# Altium schematic sheet instaspin_bldc_driver.SchDoc
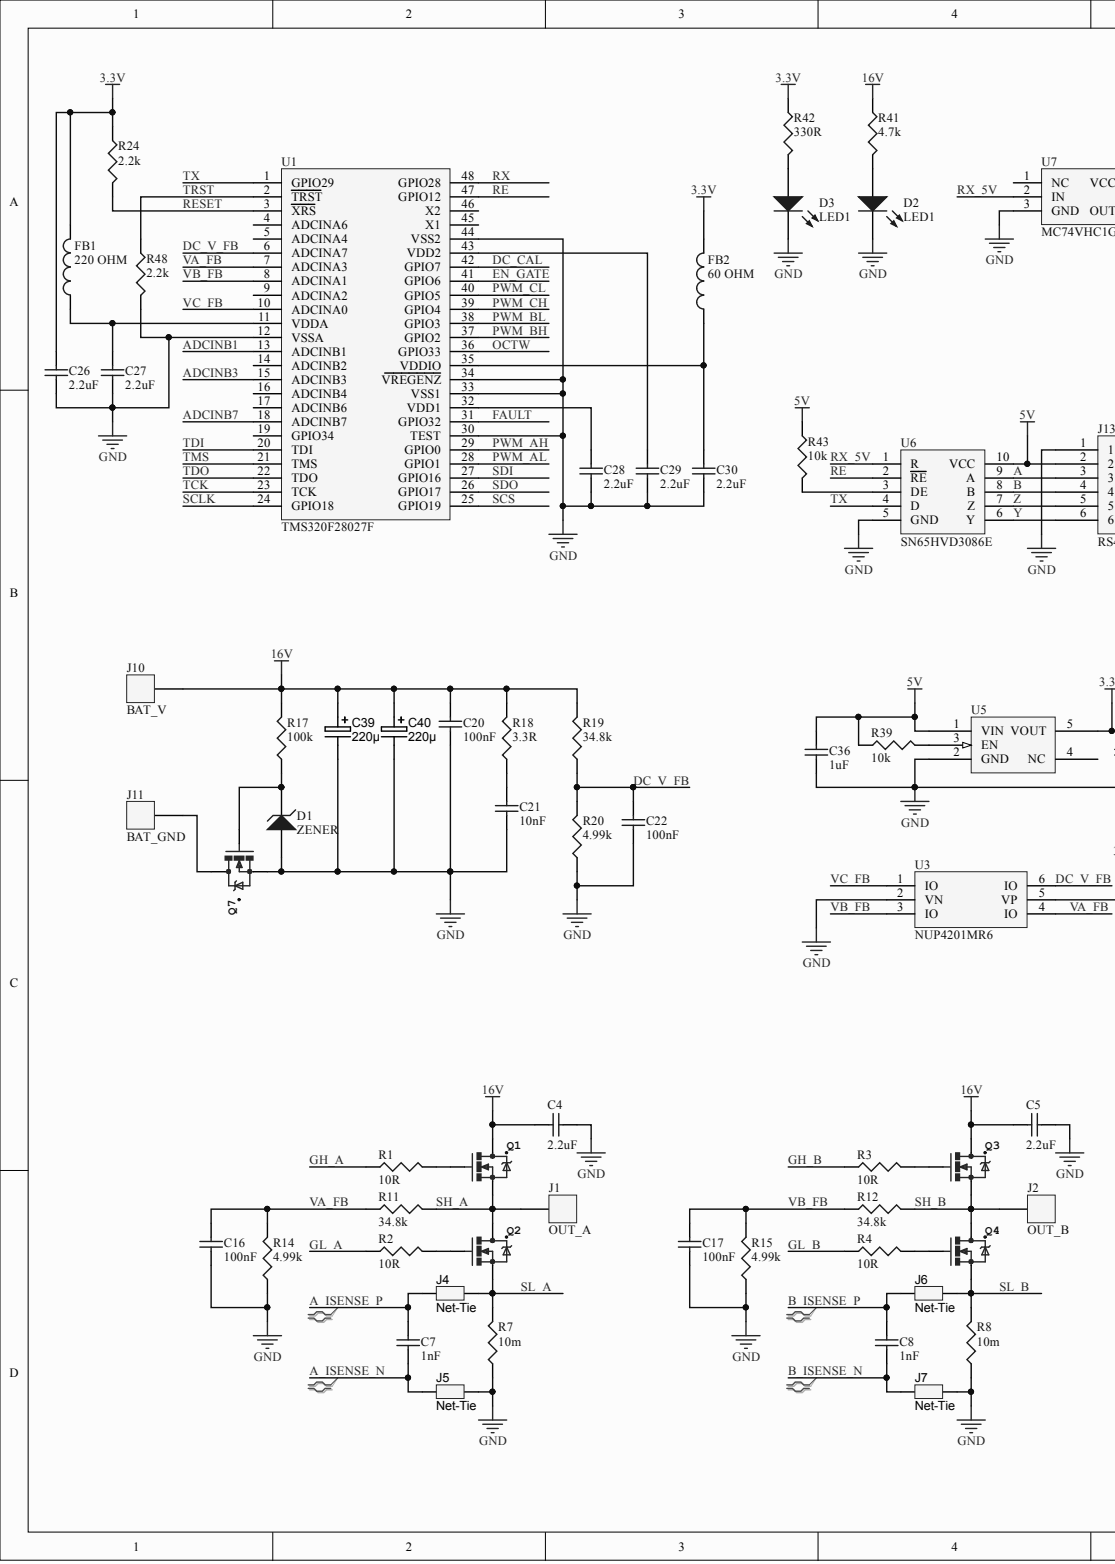
[diagram p0: instaspin_bldc_driver.SchDoc, left]
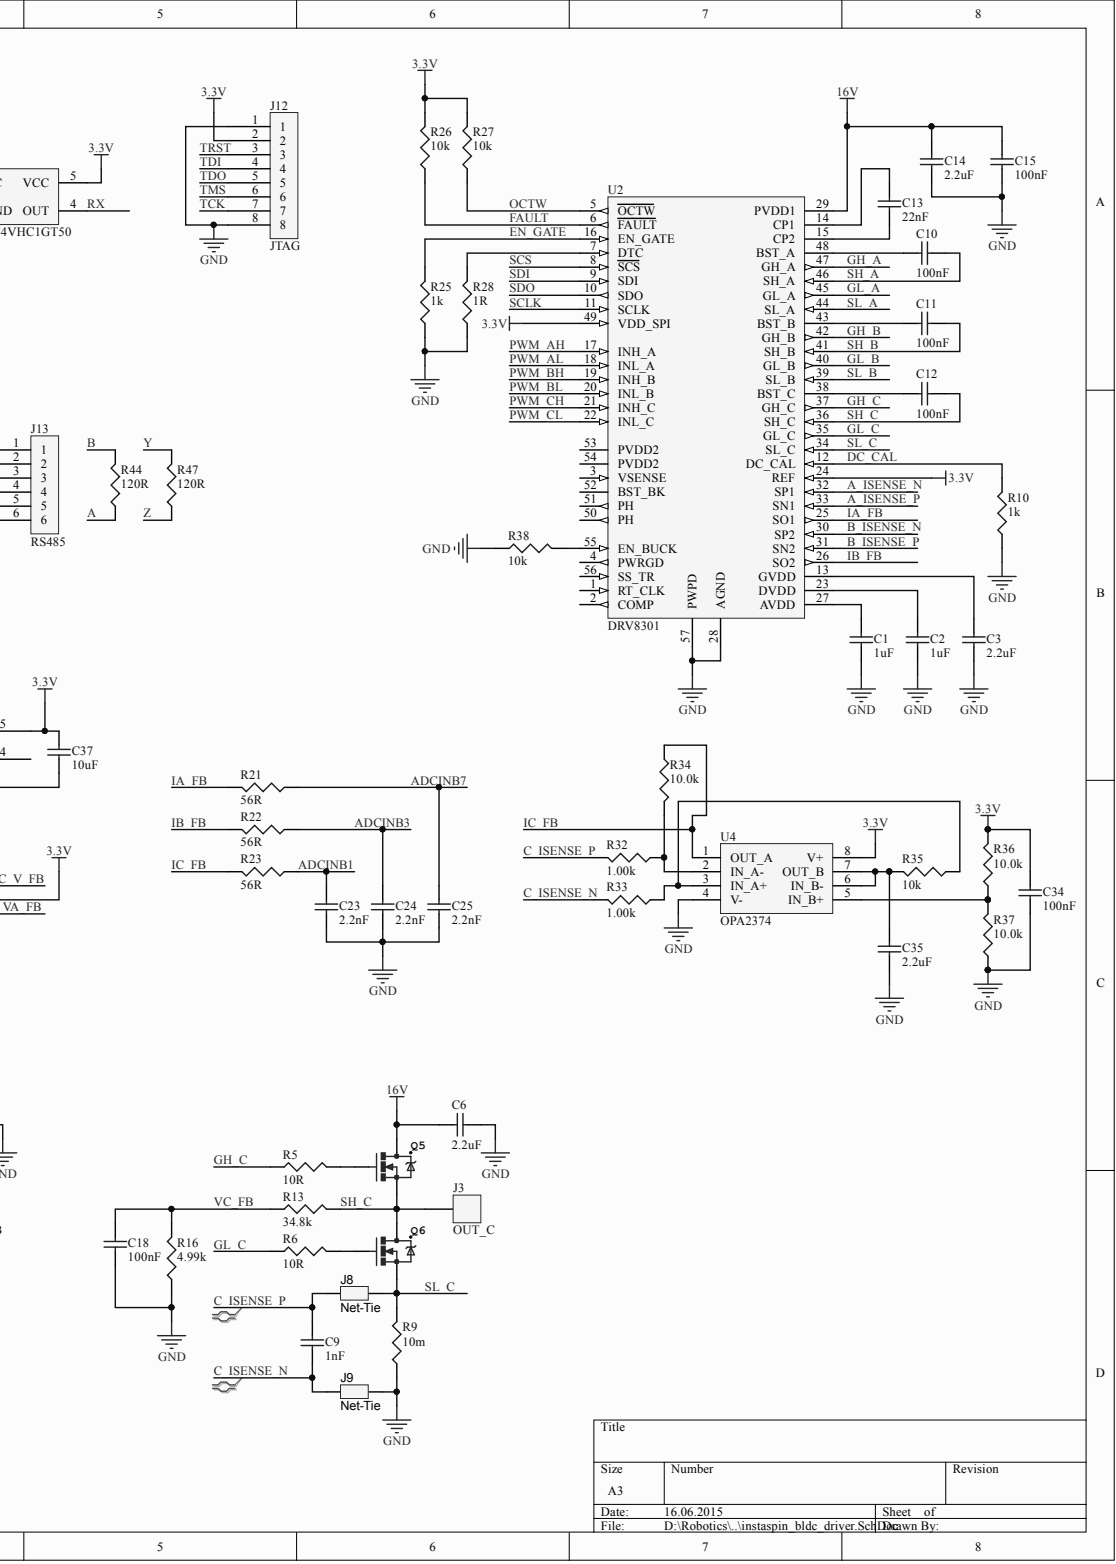
[diagram p1: instaspin_bldc_driver.SchDoc, right]

## Parts
C1  1uF  [1608[0603]]
C2  1uF  [1608[0603]]
C3  2.2uF  [1608[0603]]
C4  2.2uF  [C1206]
C5  2.2uF  [C1206]
C6  2.2uF  [C1206]
C7  1nF  [1608[0603]]
C8  1nF  [1608[0603]]
C9  1nF  [1608[0603]]
C10  100nF  [1608[0603]]
C11  100nF  [1608[0603]]
C12  100nF  [1608[0603]]
C13  22nF  [1608[0603]]
C14  2.2uF  [C1206]
C15  100nF  [1608[0603]]
C16  100nF  [1608[0603]]
C17  100nF  [1608[0603]]
C18  100nF  [1608[0603]]
C20  100nF  [1608[0603]]
C21  10nF  [1608[0603]]
C22  100nF  [1608[0603]]
C23  2.2nF  [1608[0603]]
C24  2.2nF  [1608[0603]]
C25  2.2nF  [1608[0603]]
C26  2.2uF  [1608[0603]]
C27  2.2uF  [1608[0603]]
C28  2.2uF  [1608[0603]]
C29  2.2uF  [1608[0603]]
C30  2.2uF  [1608[0603]]
C34  100nF  [1608[0603]]
C35  2.2uF  [1608[0603]]
C36  1uF  [1608[0603]]
C37  10uF  [C1206]
C39  220µ  [CAP_ELEC_6.3x4.2]
C40  220µ  [CAP_ELEC_6.3x4.2]
D1  ZENER  [SODFL370X135-2N]
D2  LED1  [1608[0603]]
D3  LED1  [1608[0603]]
FB1  220 OHM  [INDC1608L]
FB2  60 OHM  [INDC1608L]
J1  OUT_A  [POWER-PAD]
J2  OUT_B  [POWER-PAD]
J3  OUT_C  [POWER-PAD]
J4  Net-Tie  [Net-Tie_10mil]
J5  Net-Tie  [Net-Tie_10mil]
J6  Net-Tie  [Net-Tie_10mil]
J7  Net-Tie  [Net-Tie_10mil]
J8  Net-Tie  [Net-Tie_10mil]
J9  Net-Tie  [Net-Tie_10mil]
J10  BAT_V  [POWER-PAD]
J11  BAT_GND  [POWER-PAD]
J12  JTAG  [MICRO-MATCH-8]
J13  RS485  [MICRO-MATCH-6]
Q1  MOSFET-N  [SOT669]  (value not drawn)
Q2  MOSFET-N  [SOT669]  (value not drawn)
Q3  MOSFET-N  [SOT669]  (value not drawn)
Q4  MOSFET-N  [SOT669]  (value not drawn)
Q5  MOSFET-N  [SOT669]  (value not drawn)
Q6  MOSFET-N  [SOT669]  (value not drawn)
Q7  MOSFET-N  [SOT669]  (value not drawn)
R1  10R  [J1-0603]
R2  10R  [J1-0603]
R3  10R  [J1-0603]
R4  10R  [J1-0603]
R5  10R  [J1-0603]
R6  10R  [J1-0603]
R7  10m  [2512M]
R8  10m  [2512M]
R9  10m  [2512M]
R10  1k  [J1-0603]
R11  34.8k  [J1-0603]
R12  34.8k  [J1-0603]
R13  34.8k  [J1-0603]
R14  4.99k  [J1-0603]
R15  4.99k  [J1-0603]
R16  4.99k  [J1-0603]
R17  100k  [J1-0603]
R18  3.3R  [C1206]
R19  34.8k  [J1-0603]
R20  4.99k  [J1-0603]
R21  56R  [J1-0603]
R22  56R  [J1-0603]
R23  56R  [J1-0603]
R24  2.2k  [J1-0603]
R25  1k  [J1-0603]
R26  10k  [J1-0603]
R27  10k  [J1-0603]
R28  1R  [J1-0603]
R32  1.00k  [J1-0603]
R33  1.00k  [J1-0603]
R34  10.0k  [J1-0603]
R35  10k  [J1-0603]
R36  10.0k  [J1-0603]
R37  10.0k  [J1-0603]
R38  10k  [J1-0603]
R39  10k  [J1-0603]
R41  4.7k  [J1-0603]
R42  330R  [J1-0603]
R43  10k  [J1-0603]
R44  120R  [J1-0603]
R47  120R  [J1-0603]
R48  2.2k  [J1-0603]
U1  TMS320F28027F  [PQFP48]
U2  DRV8301  [SO56_POWERPAD]
U3  NUP4201MR6  [TSOP-6]
U4  OPA2374  [SOT23-8]
U5  LDO-SOT23-5  [SOT23-5]  (value not drawn)
U6  SN65HVD3086E  [SOP10]
U7  MC74VHC1GT50  [SC70-5]

## Nets
3.3V: C26.2 C34.2 C37.2 FB1.2 FB2.2 J12.2 R24.1 R26.2 R27.2 R36.2 R42.1 U2.24(REF) U2.49(VDD_SPI) U3.5(VP) U4.8(V+) U5.5(VOUT) U7.5(VCC)
5V: C36.2 J13.2 R39.1 R43.1 U5.1(VIN) U6.10(VCC)
16V: C4.1 C5.1 C6.1 C14.2 C15.1 C20.2 C39.1 C40.1 J10.1 Q1.D Q3.D Q5.D R17.2 R18.2 R19.2 R41.1 U2.29(PVDD1)
GND: C1.1 C2.1 C3.1 C4.2 C5.2 C6.2 C14.1 C15.2 C16.1 C17.1 C18.1 C20.1 C21.1 C22.1 C23.1 C24.1 C25.1 C26.1 C27.1 C28.1 C29.1 C30.1 C34.1 C35.1 C36.1 C37.1 C39.2 C40.2 D1.2 D2.2 D3.2 J5.2 J7.2 J9.2 J12.1 J12.8 J13.1 Q7.S R7.1 R8.1 R9.1 R10.1 R14.1 R15.1 R16.1 R20.1 R25.1 R28.1 R37.1 R38.1 R48.2 U1.12(VSSA) U1.30(TEST) U1.33(VSS1) U1.34(VREGENZ) U1.44(VSS2) U2.28(AGND) U2.57(PWPD) U3.2(VN) U4.4(V-) U5.2(GND) U6.5(GND) U7.3(GND)
A: J13.3 R44.1 U6.9(A)
A_ISENSE_N: C7.1 J5.1 U2.32(SP1)
A_ISENSE_P: C7.2 J4.1 U2.33(SN1)
ADCINB1: C23.2 R23.1 U1.13(ADCINB1)
ADCINB3: C24.2 R22.1 U1.15(ADCINB3)
ADCINB7: C25.2 R21.1 U1.18(ADCINB7)
B: J13.4 R44.2 U6.8(B)
B_ISENSE_N: C8.1 J7.1 U2.30(SP2)
B_ISENSE_P: C8.2 J6.1 U2.31(SN2)
C_ISENSE_N: C9.1 J9.1 R33.2
C_ISENSE_P: C9.2 J8.1 R32.2
DC_CAL: R10.2 U1.42(GPIO7) U2.12(DC_CAL)
DC_V_FB: C22.2 R19.1 R20.2 U1.6(ADCINA7) U3.6(IO)
EN_GATE: R25.2 U1.41(GPIO6) U2.16(EN_GATE)
FAULT: R26.1 U1.31(GPIO32) U2.6(FAULT)
GH_A: R1.1 U2.47(GH_A)
GH_B: R3.1 U2.42(GH_B)
GH_C: R5.1 U2.37(GH_C)
GL_A: R2.1 U2.45(GL_A)
GL_B: R4.1 U2.40(GL_B)
GL_C: R6.1 U2.35(GL_C)
IA_FB: R21.2 U2.25(SO1)
IB_FB: R22.2 U2.26(SO2)
IC_FB: R23.2 R34.1 U4.1(OUT_A)
OCTW: R27.1 U1.36(GPIO33) U2.5(OCTW)
PWM_AH: U1.29(GPIO0) U2.17(INH_A)
PWM_AL: U1.28(GPIO1) U2.18(INL_A)
PWM_BH: U1.37(GPIO2) U2.19(INH_B)
PWM_BL: U1.38(GPIO3) U2.20(INL_B)
PWM_CH: U1.39(GPIO4) U2.21(INH_C)
PWM_CL: U1.40(GPIO5) U2.22(INL_C)
RE: U1.47(GPIO12) U6.2(RE)
RESET: R24.2 U1.3(XRS)
RX: U1.48(GPIO28) U7.4(OUT)
RX_5V: U6.1(R) U7.2(IN)
SCLK: U1.24(GPIO18) U2.11(SCLK)
SCS: U1.25(GPIO19) U2.8(SCS)
SDI: U1.27(GPIO16) U2.9(SDI)
SDO: U1.26(GPIO17) U2.10(SDO)
SH_A: C10.1 J1.1 Q1.S Q2.D R11.2 U2.46(SH_A)
SH_B: C11.1 J2.1 Q3.S Q4.D R12.2 U2.41(SH_B)
SH_C: C12.1 J3.1 Q5.S Q6.D R13.2 U2.36(SH_C)
SL_A: J4.2 Q2.S R7.2 U2.44(SL_A)
SL_B: J6.2 Q4.S R8.2 U2.39(SL_B)
SL_C: J8.2 Q6.S R9.2 U2.34(SL_C)
TCK: J12.7 U1.23(TCK)
TDI: J12.4 U1.20(TDI)
TDO: J12.5 U1.22(TDO)
TMS: J12.6 U1.21(TMS)
TRST: J12.3 R48.1 U1.2(TRST)
TX: U1.1(GPIO29) U6.4(D)
VA_FB: C16.2 R11.1 R14.2 U1.7(ADCINA3) U3.4(IO)
VB_FB: C17.2 R12.1 R15.2 U1.8(ADCINA1) U3.3(IO)
VC_FB: C18.2 R13.1 R16.2 U1.10(ADCINA0) U3.1(IO)
Y: J13.6 R47.2 U6.6(Y)
Z: J13.5 R47.1 U6.7(Z)
NetC1_2: C1.2 U2.27(AVDD)
NetC2_2: C2.2 U2.23(DVDD)
NetC3_2: C3.2 U2.13(GVDD)
NetC10_2: C10.2 U2.48(BST_A)
NetC11_2: C11.2 U2.43(BST_B)
NetC12_2: C12.2 U2.38(BST_C)
NetC13_1: C13.1 U2.14(CP1)
NetC13_2: C13.2 U2.15(CP2)
NetC21_2: C21.2 R18.1
NetC27_2: C27.2 FB1.1 U1.11(VDDA)
NetC28_2: C28.2 U1.32(VDD1)
NetC29_2: C29.2 U1.43(VDD2)
NetC30_2: C30.2 FB2.1 U1.35(VDDIO)
NetC35_2: C35.2 R35.2 U4.6(IN_B-) U4.7(OUT_B)
NetD1_1: D1.1 Q7.G R17.1
NetD2_1: D2.1 R41.2
NetD3_1: D3.1 R42.2
NetJ11_1: J11.1 Q7.D
NetQ1_G: Q1.G R1.2
NetQ2_G: Q2.G R2.2
NetQ3_G: Q3.G R3.2
NetQ4_G: Q4.G R4.2
NetQ5_G: Q5.G R5.2
NetQ6_G: Q6.G R6.2
NetR28_2: R28.2 U2.7(DTC)
NetR32_1: R32.1 R34.2 U4.2(IN_A-)
NetR33_1: R33.1 R35.1 U4.3(IN_A+)
NetR36_1: R36.1 R37.2 U4.5(IN_B+)
NetR38_2: R38.2 U2.55(EN_BUCK)
NetR39_2: R39.2 U5.3(EN)
NetR43_2: R43.2 U6.3(DE)

Not connected: U1 4(ADCINA6) 5(ADCINA4) 9(ADCINA2) 14(ADCINB2) 16(ADCINB4) 17(ADCINB6) 19(GPIO34) 45(X1) 46(X2); U2 1(RT_CLK) 2(COMP) 3(VSENSE) 4(PWRGD) 50(PH) 51(PH) 52(BST_BK) 53(PVDD2) 54(PVDD2) 56(SS_TR); U5 4(NC); U7 1(NC)

## Library data of the parts
C1-C18 C20-C30 C34-C37 = Cap Semi: Capacitor (Semiconductor SIM Model); Value: 100pF; Component Kind: Standard; LatestRevisionDate: 29-May-2009; LatestRevisionNote: IPC-7351 Footprint Added.; Library Name: Miscellaneous Devices.IntLib; PackageDescription: Chip Capacitor; PackageReference: C1206; Published: 8-Jun-2000; Signal Integrity: Cap Semi; Simulation: CAP; Publisher: Altium Limited
C39 C40 = CAPE_470u_16V_THT: Capacitor THT Alum Elec 470uF 20% 16V; Manufacturer 1: NICHICON; Manufacturer Part Number 1: UHM1C471MPD6; Material: Al Electrolytic; Ratings: 16V; 105°C; SCDType: undef; Component Kind: Standard; Library Name: PBL - Capacitors.SCHLIB; Manufacturer 2: JD; Manufacturer Part Number 2: GT470UF16V_10x12mm; Package / Case: Radial; Supplier 1: Newark; Supplier Part Number 1: 54K8151; Tolerance: ±20%; Value: 220µ
D1 = ZENER-DIODE: Component Kind: Standard; Library Name: power.IntLib
D2 D3 = LED1: Typical RED GaAs LED; Component Kind: Standard; LatestRevisionDate: 08-Jul-2005; LatestRevisionNote: Mech Layer 1 removed.; Library Name: Miscellaneous Devices.IntLib; PackageDescription: LED; 2 Leads; PackageReference: LED-1; Published: 8-Jun-2000; Simulation: LED1; Publisher: Altium Limited
FB1 FB2 = Inductor: Ferrite; Code_IEC: 1005; Value: 10mH; Code_JEITA: 0402; Component Kind: Standard; LatestRevisionDate: 29-May-2009; LatestRevisionNote: IPC-7351 Footprint Added.; Library Name: Miscellaneous Devices.IntLib; PackageDescription: Chip Inductor; PackageReference: 0402-A; Published: 8-Jun-2000; Signal Integrity: Inductor; Simulation: INDUCTOR; Publisher: Altium Limited
J1-J3 J10 J11 = HEADER-1: Component Kind: Standard; Library Name: connectors.IntLib
J4-J9 = Net-Tie: Single point connection between nets.; SymbolCreator: codlsc; Component Kind: Net Tie; LatestRevisionDate: 2013-05-15; LatestRevisionNote: Added Alternate PartNumber and Alternate Manufacturer parameters to appropriate components.; MountType: SMT; SymbolVersion: 2009-07-01; Library Name: BOOSTXL-DRV8301.IntLib; Publisher: Texas Instruments
J12 = HEADER-8: Component Kind: Standard; Library Name: connectors.IntLib
J13 = HEADER-6: Component Kind: Standard; Library Name: connectors.IntLib
Q1-Q7 = MOSFET-N: PROD_ID: IC-08089; Component Kind: Standard; Library Name: mosfets.IntLib
R1-R9 R11-R24 R26-R28 R32-R39 R41-R44 R47 R48 = Res3: Resistor; Value: 1K; Component Kind: Standard; LatestRevisionDate: 29-May-2009; LatestRevisionNote: IPC-7351 Footprint Added.; Library Name: Miscellaneous Devices.IntLib; PackageDescription: Chip Resistor; PackageReference: J1-0603; Published: 8-Jun-2000; Signal Integrity: Res; Simulation: RESISTOR; Publisher: Altium Limited
R10 R25 = Res3: Resistor; Component Kind: Standard; LatestRevisionDate: 29-May-2009; LatestRevisionNote: IPC-7351 Footprint Added.; Library Name: Miscellaneous Devices.IntLib; PackageDescription: Chip Resistor; PackageReference: J1-0603; Published: 8-Jun-2000; Signal Integrity: Res; Simulation: RESISTOR; Value: 1K; Publisher: Altium Limited
U1 = TMS320F28027F: Component Kind: Standard; Library Name: texas_instruments.IntLib
U2 = DRV8301: Component Kind: Standard; Library Name: texas_instruments.IntLib
U3 = NUP4201MR6: Component Kind: Standard; Library Name: digital.IntLib
U4 = OPA2374: Component Kind: Standard; Library Name: texas_instruments.IntLib
U5 = LDO-SOT23-5: Component Kind: Standard; Library Name: power.IntLib
U6 = SN65HVD3086E: Component Kind: Standard; Library Name: texas_instruments.IntLib
U7 = MC74VHC1GT50: Component Kind: Standard; Library Name: digital.IntLib
